# Altium schematic sheet PERIPHERAL_PORT_IO.SchDoc
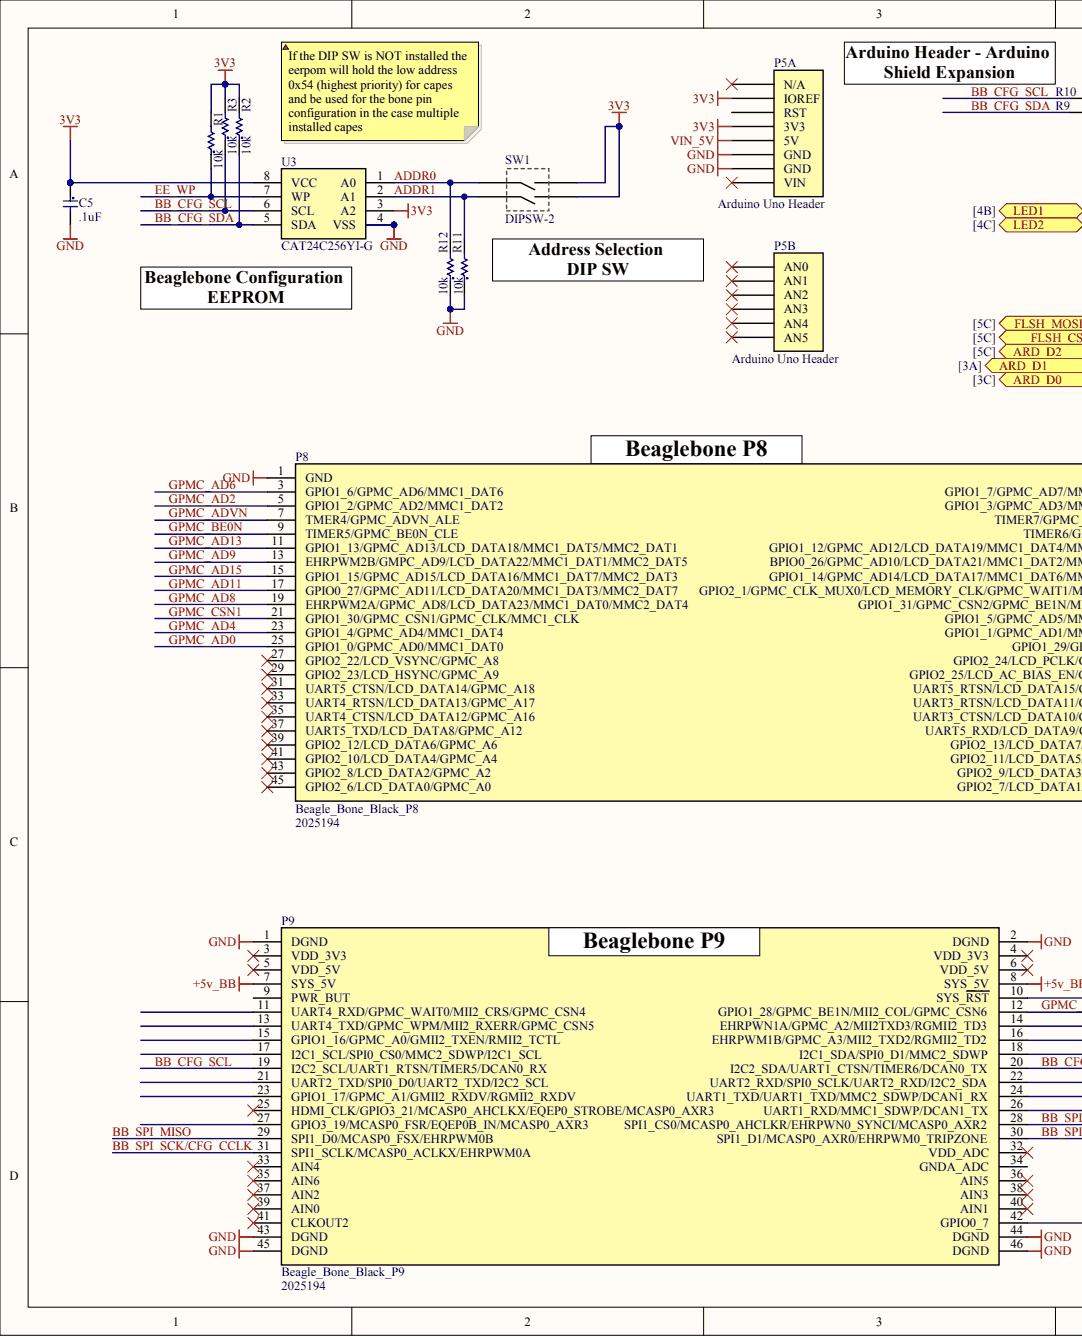
[diagram p0: PERIPHERAL_PORT_IO.SchDoc, left]
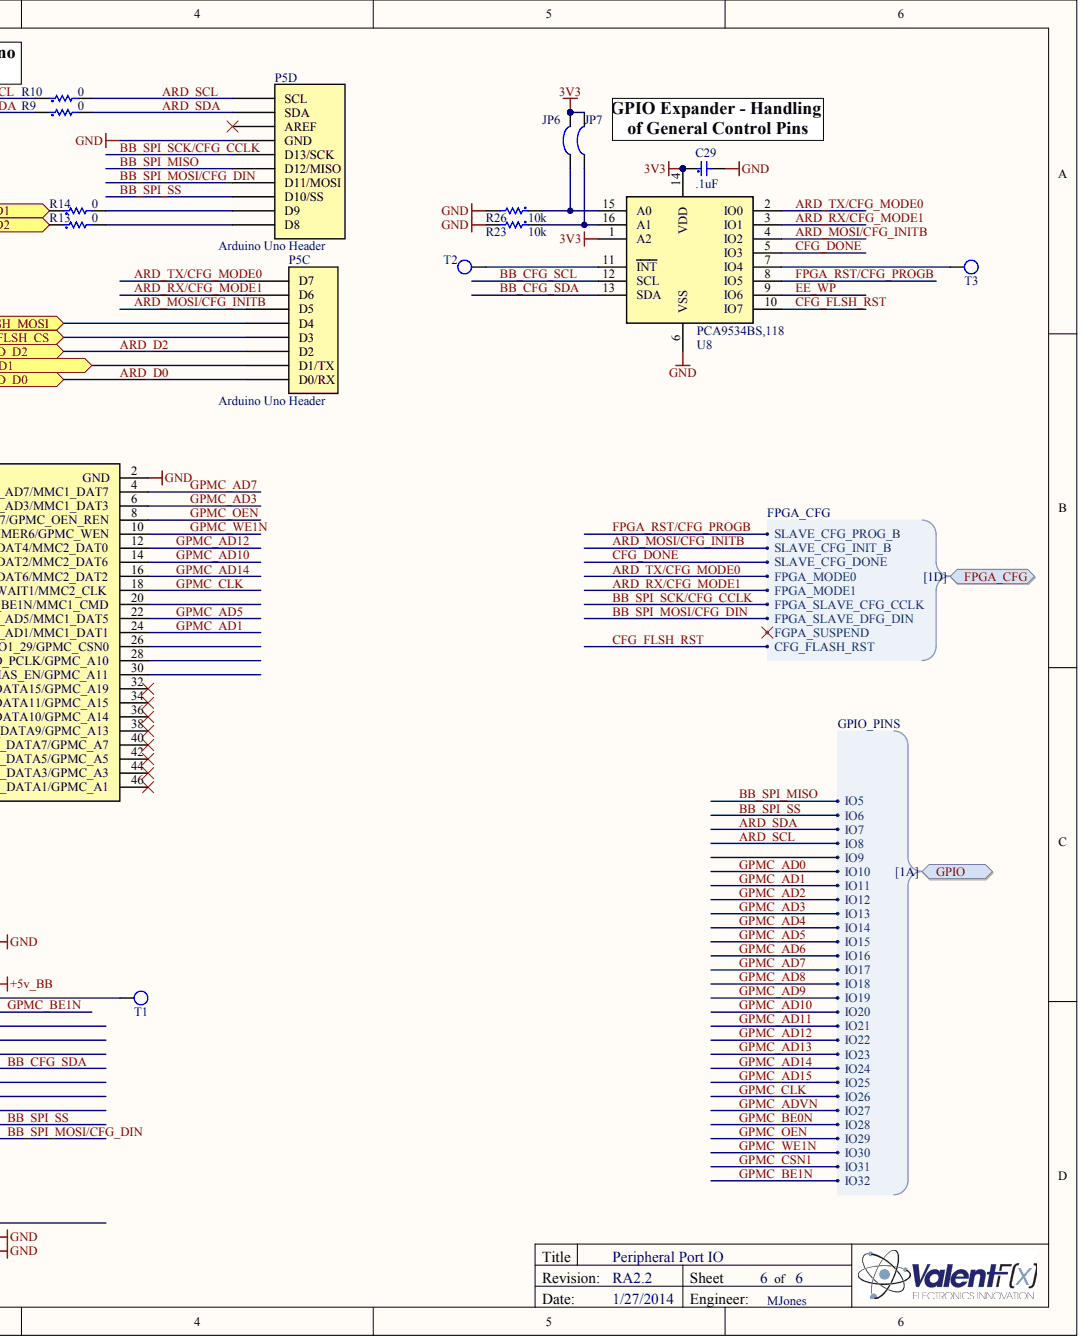
[diagram p1: PERIPHERAL_PORT_IO.SchDoc, right]

## Parts
C5  .1uF
C29  .1uF
JP6  Solder Jumper  (value not drawn)
JP7  Solder Jumper  (value not drawn)
P5  Arduino Uno Header  (parts A,B,C,D)
P8  Supplier Part Number 1: 2025194  (hidden comment: Beagle_Bone_Black_P8)
P9  Supplier Part Number 1: 2025194  (hidden comment: Beagle_Bone_Black_P9)
R1  10k
R2  10k
R3  10k
R9  0
R10  0
R11  10k
R12  10k
R13  0
R14  0
R23  10k
R26  10k
SW1  DIPSW-2
T1  Test Point  (value not drawn)
T2  Test Point  (value not drawn)
T3  Test Point  (value not drawn)
U3  CAT24C256YI-G
U8  PCA9534BS,118

## Ports
ARD_D0, ARD_D1, ARD_D2, FLSH_CS, FLSH_MOSI, FPGA_CFG, FPGA_CFG.CFG_FLASH_RST, FPGA_CFG.FGPA_SUSPEND, FPGA_CFG.FPGA_MODE0, FPGA_CFG.FPGA_MODE1, FPGA_CFG.FPGA_SLAVE_CFG_CCLK, FPGA_CFG.FPGA_SLAVE_DFG_DIN, FPGA_CFG.SLAVE_CFG_DONE, FPGA_CFG.SLAVE_CFG_INIT_B, FPGA_CFG.SLAVE_CFG_PROG_B, GPIO, GPIO.IO5, GPIO.IO6, GPIO.IO7, GPIO.IO8, GPIO.IO9, GPIO.IO10, GPIO.IO11, GPIO.IO12, GPIO.IO13, GPIO.IO14, GPIO.IO15, GPIO.IO16, GPIO.IO17, GPIO.IO18, GPIO.IO19, GPIO.IO20, GPIO.IO21, GPIO.IO22, GPIO.IO23, GPIO.IO24, GPIO.IO25, GPIO.IO26, GPIO.IO27, GPIO.IO28, GPIO.IO29, GPIO.IO30, GPIO.IO31, GPIO.IO32, LED1, LED2

## Nets
3V3: C5.1 C29.1 JP6.2 JP7.2 P5.A0(IOREF) P5.A2(3V3) R1.2 R2.2 R3.2 SW1.B1 SW1.B2 U3.3(A2) U3.8(VCC) U8.1(A2) U8.14(VDD)
+5V_BB: P9.7(SYS_5V) P9.8(SYS_5V)
GND: C5.2 C29.2 P5.A4(GND) P5.A5(GND) P5.D7(GND) P8.1(GND) P8.2(GND) P9.1(DGND) P9.2(DGND) P9.43(DGND) P9.44(DGND) P9.45(DGND) P9.46(DGND) R11.1 R12.1 R23.2 R26.2 U3.4(VSS) U8.6(VSS)
VIN_5V: P5.A3(5V)
ADDR0: R12.2 SW1.A2 U3.1(A0)
ADDR1: R11.2 SW1.A1 U3.2(A1)
ARD_D0: P5.C1(D0/RX) ; port ARD_D0
ARD_D1: P5.C2(D1/TX) ; port ARD_D1
ARD_D2: P5.C3(D2) ; port ARD_D2
ARD_MOSI/CFG_INITB: P5.C6(D5) U8.4(IO2) ; port FPGA_CFG
ARD_RX/CFG_MODE1: P5.C7(D6) U8.3(IO1) ; port FPGA_CFG
ARD_SCL: P5.D10(SCL) R10.2 ; port GPIO
ARD_SDA: P5.D9(SDA) R9.2 ; port GPIO
ARD_TX/CFG_MODE0: P5.C8(D7) U8.2(IO0) ; port FPGA_CFG
BB_CFG_SCL: P9.19(I2C2_SCL/UART1_RTSN/TIMER5/DCAN0_RX) R3.1 R10.1 U3.6(SCL) U8.12(SCL)
BB_CFG_SDA: P9.20(I2C2_SDA/UART1_CTSN/TIMER6/DCAN0_TX) R2.1 R9.1 U3.5(SDA) U8.13(SDA)
BB_SPI_MISO: P5.D5(D12/MISO) P9.29(SPI1_D0/MCASP0_FSX/EHRPWM0B) ; port GPIO
BB_SPI_MOSI/CFG_DIN: P5.D4(D11/MOSI) P9.30(SPI1_D1/MCASP0_AXR0/EHRPWM0_TRIPZONE) ; port FPGA_CFG
BB_SPI_SCK/CFG_CCLK: P5.D6(D13/SCK) P9.31(SPI1_SCLK/MCASP0_ACLKX/EHRPWM0A) ; port FPGA_CFG
BB_SPI_SS: P5.D3(D10/SS) P9.28(SPI1_CS0/MCASP0_AHCLKR/EHRPWN0_SYNCI/MCASP0_AXR2) ; port GPIO
CFG_DONE: U8.5(IO3) ; port FPGA_CFG
CFG_FLSH_RST: U8.10(IO7) ; port FPGA_CFG
EE_WP: R1.1 U3.7(WP) U8.9(IO6)
FLSH_CS: P5.C4(D3) ; port FLSH_CS
FLSH_MOSI: P5.C5(D4) ; port FLSH_MOSI
FPGA_RST/CFG_PROGB: U8.8(IO5) ; port FPGA_CFG
GPMC_AD0: P8.25(GPIO1_0/GPMC_AD0/MMC1_DAT0) ; port GPIO
GPMC_AD1: P8.24(GPIO1_1/GPMC_AD1/MMC1_DAT1) ; port GPIO
GPMC_AD2: P8.5(GPIO1_2/GPMC_AD2/MMC1_DAT2) ; port GPIO
GPMC_AD3: P8.6(GPIO1_3/GPMC_AD3/MMC1_DAT3) ; port GPIO
GPMC_AD4: P8.23(GPIO1_4/GPMC_AD4/MMC1_DAT4) ; port GPIO
GPMC_AD5: P8.22(GPIO1_5/GPMC_AD5/MMC1_DAT5) ; port GPIO
GPMC_AD6: P8.3(GPIO1_6/GPMC_AD6/MMC1_DAT6) ; port GPIO
GPMC_AD7: P8.4(GPIO1_7/GPMC_AD7/MMC1_DAT7) ; port GPIO
GPMC_AD8: P8.19(EHRPWM2A/GPMC_AD8/LCD_DATA23/MMC1_DAT0/MMC2_DAT4) ; port GPIO
GPMC_AD9: P8.13(EHRPWM2B/GMPC_AD9/LCD_DATA22/MMC1_DAT1/MMC2_DAT5) ; port GPIO
GPMC_AD10: P8.14(BPIO0_26/GPMC_AD10/LCD_DATA21/MMC1_DAT2/MMC2_DAT6) ; port GPIO
GPMC_AD11: P8.17(GPIO0_27/GPMC_AD11/LCD_DATA20/MMC1_DAT3/MMC2_DAT7) ; port GPIO
GPMC_AD12: P8.12(GPIO1_12/GPMC_AD12/LCD_DATA19/MMC1_DAT4/MMC2_DAT0) ; port GPIO
GPMC_AD13: P8.11(GPIO1_13/GPMC_AD13/LCD_DATA18/MMC1_DAT5/MMC2_DAT1) ; port GPIO
GPMC_AD14: P8.16(GPIO1_14/GPMC_AD14/LCD_DATA17/MMC1_DAT6/MMC2_DAT2) ; port GPIO
GPMC_AD15: P8.15(GPIO1_15/GPMC_AD15/LCD_DATA16/MMC1_DAT7/MMC2_DAT3) ; port GPIO
GPMC_ADVN: P8.7(TMER4/GPMC_ADVN_ALE) ; port GPIO
GPMC_BE0N: P8.9(TIMER5/GPMC_BE0N_CLE) ; port GPIO
GPMC_BE1N: P9.12(GPIO1_28/GPMC_BE1N/MII2_COL/GPMC_CSN6) ; port GPIO
GPMC_CLK: P8.18(GPIO2_1/GPMC_CLK_MUX0/LCD_MEMORY_CLK/GPMC_WAIT1/MMC2_CLK) ; port GPIO
GPMC_CSN1: P8.21(GPIO1_30/GPMC_CSN1/GPMC_CLK/MMC1_CLK) ; port GPIO
GPMC_OEN: P8.8(TIMER7/GPMC_OEN_REN) ; port GPIO
GPMC_WE1N: P8.10(TIMER6/GPMC_WEN) ; port GPIO
LED1: R14.1 ; port LED1
LED2: R13.1 ; port LED2
NetJP6_1: JP6.1 R26.1 U8.15(A0)
NetJP7_1: JP7.1 R23.1 U8.16(A1)
NetP5_D1: P5.D1(D8) R13.2
NetP5_D2: P5.D2(D9) R14.2
NetP9_10: P9.10(SYS_RST) T1.1
NetT2_1: T2.1 U8.11(INT)
NetT3_1: T3.1 U8.7(IO4)
N00256: ; port GPIO
N00257: ; port FPGA_CFG

Not connected: P5 A1(RST) A6(VIN) A-1(N/A) B1(AN0) B2(AN1) B3(AN2) B4(AN3) B5(AN4) B6(AN5) D8(AREF); P8 20(GPIO1_31/GPMC_CSN2/GPMC_BE1N/MMC1_CMD) 26(GPIO1_29/GPMC_CSN0) 27(GPIO2_22/LCD_VSYNC/GPMC_A8) 28(GPIO2_24/LCD_PCLK/GPMC_A10) 29(GPIO2_23/LCD_HSYNC/GPMC_A9) 30(GPIO2_25/LCD_AC_BIAS_EN/GPMC_A11) 31(UART5_CTSN/LCD_DATA14/GPMC_A18) 32(UART5_RTSN/LCD_DATA15/GPMC_A19) 33(UART4_RTSN/LCD_DATA13/GPMC_A17) 34(UART3_RTSN/LCD_DATA11/GPMC_A15) 35(UART4_CTSN/LCD_DATA12/GPMC_A16) 36(UART3_CTSN/LCD_DATA10/GPMC_A14) 37(UART5_TXD/LCD_DATA8/GPMC_A12) 38(UART5_RXD/LCD_DATA9/GPMC_A13) 39(GPIO2_12/LCD_DATA6/GPMC_A6) 40(GPIO2_13/LCD_DATA7/GPMC_A7) 41(GPIO2_10/LCD_DATA4/GPMC_A4) 42(GPIO2_11/LCD_DATA5/GPMC_A5) 43(GPIO2_8/LCD_DATA2/GPMC_A2) 44(GPIO2_9/LCD_DATA3/GPMC_A3) 45(GPIO2_6/LCD_DATA0/GPMC_A0) 46(GPIO2_7/LCD_DATA1/GPMC_A1); P9 3(VDD_3V3) 4(VDD_3V3) 5(VDD_5V) 6(VDD_5V) 9(PWR_BUT) 11(UART4_RXD/GPMC_WAIT0/MII2_CRS/GPMC_CSN4) 13(UART4_TXD/GPMC_WPM/MII2_RXERR/GPMC_CSN5) 14(EHRPWN1A/GPMC_A2/MII2TXD3/RGMII2_TD3) 15(GPIO1_16/GPMC_A0/GMII2_TXEN/RMII2_TCTL) 16(EHRPWM1B/GPMC_A3/MII2_TXD2/RGMII2_TD2) 17(I2C1_SCL/SPI0_CS0/MMC2_SDWP/I2C1_SCL) 18(I2C1_SDA/SPI0_D1/MMC2_SDWP) 21(UART2_TXD/SPI0_D0/UART2_TXD/I2C2_SCL) 22(UART2_RXD/SPI0_SCLK/UART2_RXD/I2C2_SDA) 23(GPIO1_17/GPMC_A1/GMII2_RXDV/RGMII2_RXDV) 24(UART1_TXD/UART1_TXD/MMC2_SDWP/DCAN1_RX) 25(HDMI_CLK/GPIO3_21/MCASP0_AHCLKX/EQEP0_STROBE/MCASP0_AXR3) 26(UART1_RXD/MMC1_SDWP/DCAN1_TX) 27(GPIO3_19/MCASP0_FSR/EQEP0B_IN/MCASP0_AXR3) 32(VDD_ADC) 33(AIN4) 34(GNDA_ADC) 35(AIN6) 36(AIN5) 37(AIN2) 38(AIN3) 39(AIN0) 40(AIN1) 41(CLKOUT2) 42(GPIO0_7)

## Library data of the parts
C5 C29 = (no symbol name): Manufacturer: Murata; Manufacturer Part Number: GRM155R61C104KA88D; Capacitance: 0.1 uF; Tolerance: 10 %; Voltage Rating: 16 Volts; Temperature Coefficient / Code: X5R; Supplier 1: Mouser; RoHS: RoHS Compliant By Exemption; Category: Multilayer Ceramic Capacitors (MLCC) - SMD/SMT; Stock 1: 478408; Pricing 1: 1=0.122, 50=0.034, 100=0.026, 500=0.014, 1000=0.009, 10000=0.005, 20000=0.004 (AUD); Dimensions: 0.5 mm W x 1 mm L x 0.5 mm H; Operating Temperature Range: - 55 C to + 85 C; Package / Case: 0402 (1005 metric); Packaging: Reel; Product: General Type MLCCs; Series: GRM; Termination Style: SMD/SMT; Product Category: Low & Medium Capacitance SMD Ceramic Chip Capacitors; Standard Pack Qty: 10000; RoHS - Mouser: E; Minimum Order Quantity: 1; Reeling: True; ComponentLink1URL: http://www.murata.com/products/catalog/pdf/c02e.pdf; ComponentLink1Description: http://www.murata.com/products/catalog/pdf/c02e.pdf; Supplier Part Number 1: 81-GRM155R61C104KA8D; Value: .1uF
P8 P9 = (no symbol name): Manufacturer: SAMTEC; Manufacturer Part Number: TSW-123-07-F-D; Pitch Spacing: 2.54; No. of Rows: 2; No. of Contacts: 46; Gender: Header; Contact Termination: Through Hole Vertical; SVHC: No SVHC (20-Jun-2013); Connector Type: Board to Board; : TSW-113-F-D; Supplier 1: Farnell; RoHS: YES; Stock 1: 100; Pricing 1: 5=3.7, 50=2.55, 100=1.99, 250=1.63, 500=1.48, 2500=1.2 (GBP); Series: TSW; Contact Plating: Gold; Contact Material: Phosphor Bronze; Row Pitch: 2.54; ComponentLink1URL: http://www.farnell.com/datasheets/1701645.pdf; ComponentLink1Description: http://www.farnell.com/datasheets/1701645.pdf; ComponentLink2URL: http://www.samtec.com/ftppub/pdf/TSW_TH.PDF; ComponentLink2Description: http://www.samtec.com/ftppub/pdf/TSW_TH.PDF; ComponentLink3URL: http://www.farnell.com/cad/1528871.zip; ComponentLink3Description: http://www.farnell.com/cad/1528871.zip
  P8: Value: Beagle_Bone_Black_P8
  P9: Value: Beagle_Bone_Black_P9
R1-R3 R11 R12 R23 R26 = (no symbol name): Manufacturer: Vishay Dale; Manufacturer Part Number: CRCW060310K0FKEA; Power (Watts): 0.1W, 1/10W; Temperature Coefficient: ±100ppm/°C; Tolerance: ±1%; Value: 10k; Supplier 1: Digi-Key; RoHS: RoHS Compliant; Category: Resistors; Stock 1: 997856; Pricing 1: 10=0.081, 50=0.0436, 200=0.0251, 1000=0.01711 (USD); Resistance (Ohms): 10K; Composition: Thick Film; Features: -; Size / Dimension: 0.061" L x 0.033" W (1.55mm x 0.85mm); Height: 0.020" (0.50mm); Packaging: Cut Tape (CT); Package / Case: 0603 (1608 Metric); ComponentLink1URL: http://www.vishay.com/doc?20035; ComponentLink1Description: http://www.vishay.com/doc?20035; Supplier Part Number 1: 541-10.0KHCT-ND; Number of Terminations: 2
R9 R10 R13 R14 = (no symbol name): Manufacturer: Vishay / Dale; Manufacturer Part Number: CRCW08050000Z0EB; Power Rating: 125 mW (1/8 W); Resistance: 0 Ohms; Voltage Rating: 150 V; Supplier 1: Mouser; RoHS: RoHS Compliant By Exemption; Category: Thick Film Resistors - SMD; Stock 1: 27996; Pricing 1: 1=0.135, 10=0.055, 100=0.031, 1000=0.022, 10000=0.005, 50000=0.004, 250000=0.003 (AUD); Package / Case: 0805 (2012 metric); Packaging: Reel; ComponentLink1URL: http://www.mouser.com/ds/2/427/dcrcwe3-109170.pdf; ComponentLink1Description: http://www.mouser.com/ds/2/427/dcrcwe3-109170.pdf; Supplier Part Number 1: 71-CRCW08050000Z0EB; Value: 0; Dimensions: 1.25 mm W x 2 mm L x 0.45 mm H; Operating Temperature Range: - 55 C to + 155 C; Product: Thick Film Resistors Zero-Ohm; Series: CRCW; Termination Style: SMD/SMT; Case Code - in: 0805; Case Code - mm: 2012; Product Category: 0805 Thick Film Chip Resistors; Standard Pack Qty: 10000; RoHS - Mouser: E; Minimum Order Quantity: 1; Reeling: True
SW1 = (no symbol name): Manufacturer: CTS Electronic Components; Manufacturer Part Number: 219-2MST; Number of Positions: 2; Switch Type: DIP; Supplier 1: Mouser; RoHS: RoHS Compliant; Category: DIP Switches / SIP Switches; Stock 1: 3237; Pricing 1: 1=0.580, 10=0.530, 100=0.480, 500=0.350, 1000=0.300, 5000=0.270 (USD); Operating Temperature Range: - 55 C to + 85 C; Packaging: Tube; Terminal Pitch: 2.54 mm; Termination Style: SMD/SMT; Product Category: DIP Switches; RoHS - Mouser: Y; Minimum Order Quantity: 1; Reeling: False; ComponentLink1URL: http://www.ctscorp.com/components/Datasheets/219.pdf; ComponentLink1Description: http://www.ctscorp.com/components/Datasheets/219.pdf; Supplier Part Number 1: 774-2192MST
U3 = (no symbol name): Manufacturer: ON Semiconductor; Format - Memory: EEPROMs - Serial; Memory Type: EEPROM; Memory Size: 256K (32K x 8); Speed: 400kHz; Interface: I²C, 2-Wire Serial; Voltage - Supply: 1.8 V ~ 5.5 V; Supplier 1: Digi-Key; Manufacturer Part Number: CAT24C256YI-G; RoHS: RoHS Compliant; Category: Integrated Circuits (ICs); Stock 1: 840; Pricing 1: 1=0.97, 10=0.845, 25=0.7488, 100=0.6521, 250=0.56752, 500=0.483, 1000=0.3864, 2500=0.35018, 5000=0.32603 (USD); Operating Temperature: -40°C ~ 85°C; Package / Case: 8-TSSOP (0.173", 4.40mm Width); Supplier Device Package: 8-TSSOP; Packaging: Tube; ComponentLink1URL: http://www.onsemi.com/pub_link/Collateral/CAT24C256-D.PDF; ComponentLink1Description: http://www.onsemi.com/pub_link/Collateral/CAT24C256-D.PDF; Supplier Part Number 1: CAT24C256YI-GOS-ND; Value: CAT24C256YI-G
U8 = (no symbol name): Manufacturer: NXP Semiconductors; Output Current: 50 mA; Logic Family: PCA; Power Dissipation: 200 mW; Operating Supply Voltage: 2.3 V to 5.5 V; Supplier 1: Mouser; Manufacturer Part Number: PCA9534BS,118; RoHS: RoHS Compliant; Category: I/O Expanders, Repeaters & Hubs; Stock 1: 6089; Pricing 1: 1=1.27, 25=1.16, 100=1.09, 250=1.04, 500=1.00, 1000=0.960, 3000=0.933, 6000=0.899, 12000=0.875 (AUD); Operating Temperature Range: - 40 C to + 85 C; Package / Case: HVQFN-16; Packaging: Reel; Mounting Style: SMD/SMT; Product Category: I/O Expanders; Standard Pack Qty: 6000; RoHS - Mouser: Y; Minimum Order Quantity: 1; Reeling: True; ComponentLink1URL: http://www.nxp.com/documents/data_sheet/PCA9534.pdf; ComponentLink1Description: http://www.nxp.com/documents/data_sheet/PCA9534.pdf; Supplier Part Number 1: 771-PCA9534BS118; Value: PCA9534BS,118
JP6 JP7 P5 T1-T3 = (no symbol name)
  JP6 JP7: Manufacturer: n/a; Manufacturer Part Number: n/a; Source: n/a; Source Part Number: n/a; ROHS Certificate: n/a
  P5: Link1: http://store.iteadstudio.com/index.php?main_page=product_info&products_id=331; Pricing 1: 1=1.00; RoHS: RoHS Compliant; Category: Connectors, Interconnects; ComponentLink1URL: http://www.hirose.co.jp/cataloge_hp/e53700036.pdf; ComponentLink1Description: http://www.hirose.co.jp/cataloge_hp/e53700036.pdf; Supplier 1: Iteadstudio; Supplier Part Number 1: 27/30
